# Altium schematic sheet JGL1904_FireAnt_FPGA_V1.3.SchDoc(JGL1904_FireAnt_FPGA_V1.3)
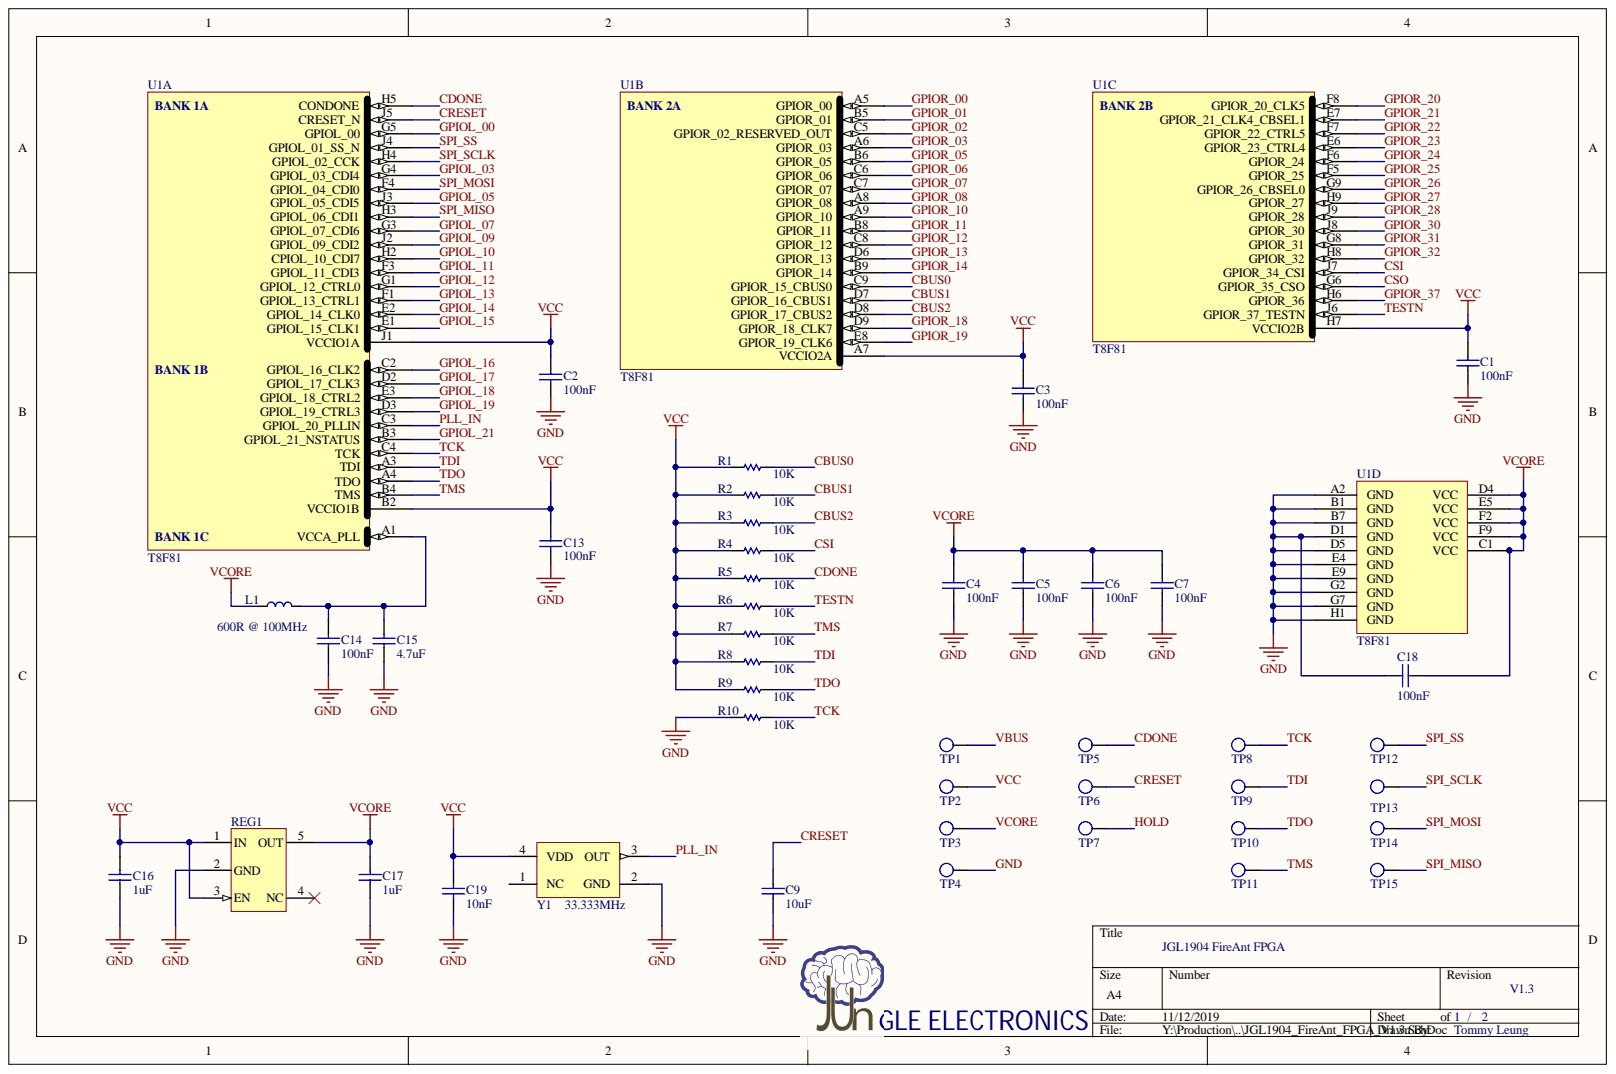
[diagram p0: JGL1904_FireAnt_FPGA_V1.3.SchDoc]

## Parts
C1  value 100nF  [CAPC0201M]  (hidden comment: CAPACITOR)
C2  value 100nF  [CAPC0201M]  (hidden comment: CAPACITOR)
C3  value 100nF  [CAPC0201M]  (hidden comment: CAPACITOR)
C4  value 100nF  [CAPC0201M]  (hidden comment: CAPACITOR)
C5  value 100nF  [CAPC0201M]  (hidden comment: CAPACITOR)
C6  value 100nF  [CAPC0201M]  (hidden comment: CAPACITOR)
C7  value 100nF  [CAPC0201M]  (hidden comment: CAPACITOR)
C9  value 10uF  [CAPC0402M]  (hidden comment: CAPACITOR)
C13  value 100nF  [CAPC0201M]  (hidden comment: CAPACITOR)
C14  value 100nF  [CAPC0201M]  (hidden comment: CAPACITOR)
C15  value 4.7uF  [CAPC0402M]  (hidden comment: CAPACITOR)
C16  value 1uF  [CAPC0402M]  (hidden comment: CAPACITOR)
C17  value 1uF  [CAPC0402M]  (hidden comment: CAPACITOR)
C18  value 100nF  [CAPC0201M]  (hidden comment: CAPACITOR)
C19  value 10nF  [CAPC0402M]  (hidden comment: CAPACITOR)
L1  value 600R @ 100MHz  [RESC0603M]  (hidden comment: FERRITE_BEADS)
R1  value 10K  [RESC0402M]  (hidden comment: RESISTOR)
R2  value 10K  [RESC0402M]  (hidden comment: RESISTOR)
R3  value 10K  [RESC0402M]  (hidden comment: RESISTOR)
R4  value 10K  [RESC0402M]  (hidden comment: RESISTOR)
R5  value 10K  [RESC0402M]  (hidden comment: RESISTOR)
R6  value 10K  [RESC0402M]  (hidden comment: RESISTOR)
R7  value 10K  [RESC0402M]  (hidden comment: RESISTOR)
R8  value 10K  [RESC0402M]  (hidden comment: RESISTOR)
R9  value 10K  [RESC0402M]  (hidden comment: RESISTOR)
R10  value 10K  [RESC0402M]  (hidden comment: RESISTOR)
REG1  TLV743P  [SOT-23-5]  (value not drawn)
TP1  TEST_POINT  [TP-SMD]  (value not drawn)
TP2  TEST_POINT  [TP-SMD]  (value not drawn)
TP3  TEST_POINT  [TP-SMD]  (value not drawn)
TP4  TEST_POINT  [TP-SMD]  (value not drawn)
TP5  TEST_POINT  [TP-SMD]  (value not drawn)
TP6  TEST_POINT  [TP-SMD]  (value not drawn)
TP7  TEST_POINT  [TP-SMD]  (value not drawn)
TP8  TEST_POINT  [TP-SMD]  (value not drawn)
TP9  TEST_POINT  [TP-SMD]  (value not drawn)
TP10  TEST_POINT  [TP-SMD]  (value not drawn)
TP11  TEST_POINT  [TP-SMD]  (value not drawn)
TP12  TEST_POINT  [TP-SMD]  (value not drawn)
TP13  TEST_POINT  [TP-SMD]  (value not drawn)
TP14  TEST_POINT  [TP-SMD]  (value not drawn)
TP15  TEST_POINT  [TP-SMD]  (value not drawn)
U1  T8F81  [BGA-81]  (parts A,B,C,D)
Y1  value 33.333MHz  [XTAL-3225]  (hidden comment: XTAL_SO3225)

## Nets
CBUS0: R1.1 U1.C9(GPIOR_15_CBUS0)
CBUS1: R2.1 U1.D7(GPIOR_16_CBUS1)
CBUS2: R3.1 U1.D8(GPIOR_17_CBUS2)
CDONE: R5.1 TP5.1 U1.H5(CONDONE)
CRESET: C9.1 TP6.1 U1.J5(CRESET_N)
CSI: R4.1 U1.J7(GPIOR_34_CSI)
CSO: U1.G6(GPIOR_35_CSO)
GND: C1.1 C2.1 C3.1 C4.1 C5.1 C6.1 C7.1 C9.2 C13.1 C14.1 C15.1 C16.2 C17.2 C18.2 C19.2 R10.2 REG1.2(GND) TP4.1 U1.A2(GND) U1.B1(GND) U1.B7(GND) U1.D1(GND) U1.D5(GND) U1.E4(GND) U1.E9(GND) U1.G2(GND) U1.G7(GND) U1.H1(GND) Y1.2(GND)
GPIOL_00: U1.G5(GPIOL_00)
GPIOL_03: U1.G4(GPIOL_03_CDI4)
GPIOL_05: U1.J3(GPIOL_05_CDI5)
GPIOL_07: U1.G3(GPIOL_07_CDI6)
GPIOL_09: U1.J2(GPIOL_09_CDI2)
GPIOL_10: U1.H2(CPIOL_10_CDI7)
GPIOL_11: U1.F3(GPIOL_11_CDI3)
GPIOL_12: U1.G1(GPIOL_12_CTRL0)
GPIOL_13: U1.F1(GPIOL_13_CTRL1)
GPIOL_14: U1.E2(GPIOL_14_CLK0)
GPIOL_15: U1.E1(GPIOL_15_CLK1)
GPIOL_16: U1.C2(GPIOL_16_CLK2)
GPIOL_17: U1.D2(GPIOL_17_CLK3)
GPIOL_18: U1.E3(GPIOL_18_CTRL2)
GPIOL_19: U1.D3(GPIOL_19_CTRL3)
GPIOL_21: U1.B3(GPIOL_21_NSTATUS)
GPIOR_00: U1.A5(GPIOR_00)
GPIOR_01: U1.B5(GPIOR_01)
GPIOR_02: U1.C5(GPIOR_02_RESERVED_OUT)
GPIOR_03: U1.A6(GPIOR_03)
GPIOR_05: U1.B6(GPIOR_05)
GPIOR_06: U1.C6(GPIOR_06)
GPIOR_07: U1.C7(GPIOR_07)
GPIOR_08: U1.A8(GPIOR_08)
GPIOR_10: U1.A9(GPIOR_10)
GPIOR_11: U1.B8(GPIOR_11)
GPIOR_12: U1.C8(GPIOR_12)
GPIOR_13: U1.D6(GPIOR_13)
GPIOR_14: U1.B9(GPIOR_14)
GPIOR_18: U1.D9(GPIOR_18_CLK7)
GPIOR_19: U1.E8(GPIOR_19_CLK6)
GPIOR_20: U1.F8(GPIOR_20_CLK5)
GPIOR_21: U1.E7(GPIOR_21_CLK4_CBSEL1)
GPIOR_22: U1.F7(GPIOR_22_CTRL5)
GPIOR_23: U1.E6(GPIOR_23_CTRL4)
GPIOR_24: U1.F6(GPIOR_24)
GPIOR_25: U1.F5(GPIOR_25)
GPIOR_26: U1.G9(GPIOR_26_CBSEL0)
GPIOR_27: U1.H9(GPIOR_27)
GPIOR_28: U1.J9(GPIOR_28)
GPIOR_30: U1.J8(GPIOR_30)
GPIOR_31: U1.G8(GPIOR_31)
GPIOR_32: U1.H8(GPIOR_32)
GPIOR_37: U1.H6(GPIOR_36)
HOLD: TP7.1
PLL_IN: U1.C3(GPIOL_20_PLLIN) Y1.3(OUT)
SPI_MISO: TP15.1 U1.H3(GPIOL_06_CDI1)
SPI_MOSI: TP14.1 U1.F4(GPIOL_04_CDI0)
SPI_SCLK: TP13.1 U1.H4(GPIOL_02_CCK)
SPI_SS: TP12.1 U1.J4(GPIOL_01_SS_N)
TCK: R10.1 TP8.1 U1.C4(TCK)
TDI: R8.1 TP9.1 U1.A3(TDI)
TDO: R9.1 TP10.1 U1.A4(TDO)
TESTN: R6.1 U1.J6(GPIOR_37_TESTN)
TMS: R7.1 TP11.1 U1.B4(TMS)
VBUS: TP1.1
VCC: C1.2 C2.2 C3.2 C13.2 C16.1 C19.1 R1.2 R2.2 R3.2 R4.2 R5.2 R6.2 R7.2 R8.2 R9.2 REG1.1(IN) REG1.3(EN) TP2.1 U1.A7(VCCIO2A) U1.B2(VCCIO1B) U1.H7(VCCIO2B) U1.J1(VCCIO1A) Y1.4(VDD)
VCORE: C4.2 C5.2 C6.2 C7.2 C17.1 C18.1 L1.1 REG1.5(OUT) TP3.1 U1.C1(VCC) U1.D4(VCC) U1.E5(VCC) U1.F2(VCC) U1.F9(VCC)
NetC14_2: C14.2 C15.2 L1.2 U1.A1(VCCA_PLL)

Not connected: REG1 4(NC); Y1 1(NC)

## Library data of the parts
C1-C7 C13 C14 C18 = CAPACITOR: Mfr. PN: GRM033C71A104KE14D; Supplier Part Number 1: 81-GRM033C71A104KE4D; Supplier 1: Mouser; Description: Capacitor; Component Kind: Standard; Library Name: Jungle_ADLibrary.IntLib
C9 = CAPACITOR: Mfr. PN: GRM155C80J106ME1J; Supplier Part Number 1: 81-GRM155C80J106ME1J; Supplier 1: Mouser; Description: Capacitor; Component Kind: Standard; Library Name: Jungle_ADLibrary.IntLib
C15 = CAPACITOR: Mfr. PN: GRM155R60J475ME7J; Supplier Part Number 1: 81-GRM155R60J475ME7J; Supplier 1: Mouser; Description: Capacitor; Component Kind: Standard; Library Name: Jungle_ADLibrary.IntLib
C16 C17 = CAPACITOR: Mfr. PN: GCM155C71A105KE8D; Supplier Part Number 1: 81-GCM155C71A105KE8D; Supplier 1: Mouser; Description: Capacitor; Component Kind: Standard; Library Name: Jungle_ADLibrary.IntLib
C19 = CAPACITOR: Mfr. PN: GCM155R71H103KA5J; Supplier Part Number 1: 81-GCM155R71H103KA5J; Supplier 1: Mouser; Description: Capacitor; Component Kind: Standard; Library Name: Jungle_ADLibrary.IntLib
L1 = FERRITE_BEADS: Ferrite Beads; Mfr. PN: BK1608HS601-T; Supplier Part Number 1: 963-BK1608HS601-T; Supplier 1: Mouser; Component Kind: Standard; Library Name: Jungle_ADLibrary.IntLib
R1-R10 = RESISTOR: Mfr. PN: RC0402FR-0710KL; Supplier Part Number 1: 603-RC0402FR-0710KL; Supplier 1: Mouser; Description: Resistor; Component Kind: Standard; Library Name: Jungle_ADLibrary.IntLib
REG1 = TLV743P: Capacitor-Free, 300mA, Low-Dropout Regulator; Mfr. PN: TLV74312PDBVR; Value: TLV743P; Supplier Part Number 1: 595-TLV74312PDBVR; Supplier 1: Mouser; Component Kind: Standard; Library Name: Jungle_ADLibrary.IntLib
TP1-TP15 = TEST_POINT: Test Point; Component Kind: Standard; Library Name: Jungle_ADLibrary.IntLib
U1 = T8F81: Trion T8 FPGA; Mfr. PN: T8F81C2; Supplier 1: Effinix; Component Kind: Standard; Library Name: Jungle_ADLibrary.IntLib
Y1 = XTAL_SO3225A: Crystal Oscillator, SO3225, 33.333M, -+50ppm(-40~+85), 3.3V; Mfr. PN: SO3225/33.333M/-+50ppm(-40~+85)/3.3V; Component Kind: Standard; Library Name: Jungle_ADLibrary.IntLib
